# Altium schematic sheet RAK3172_Sensor.SchDoc
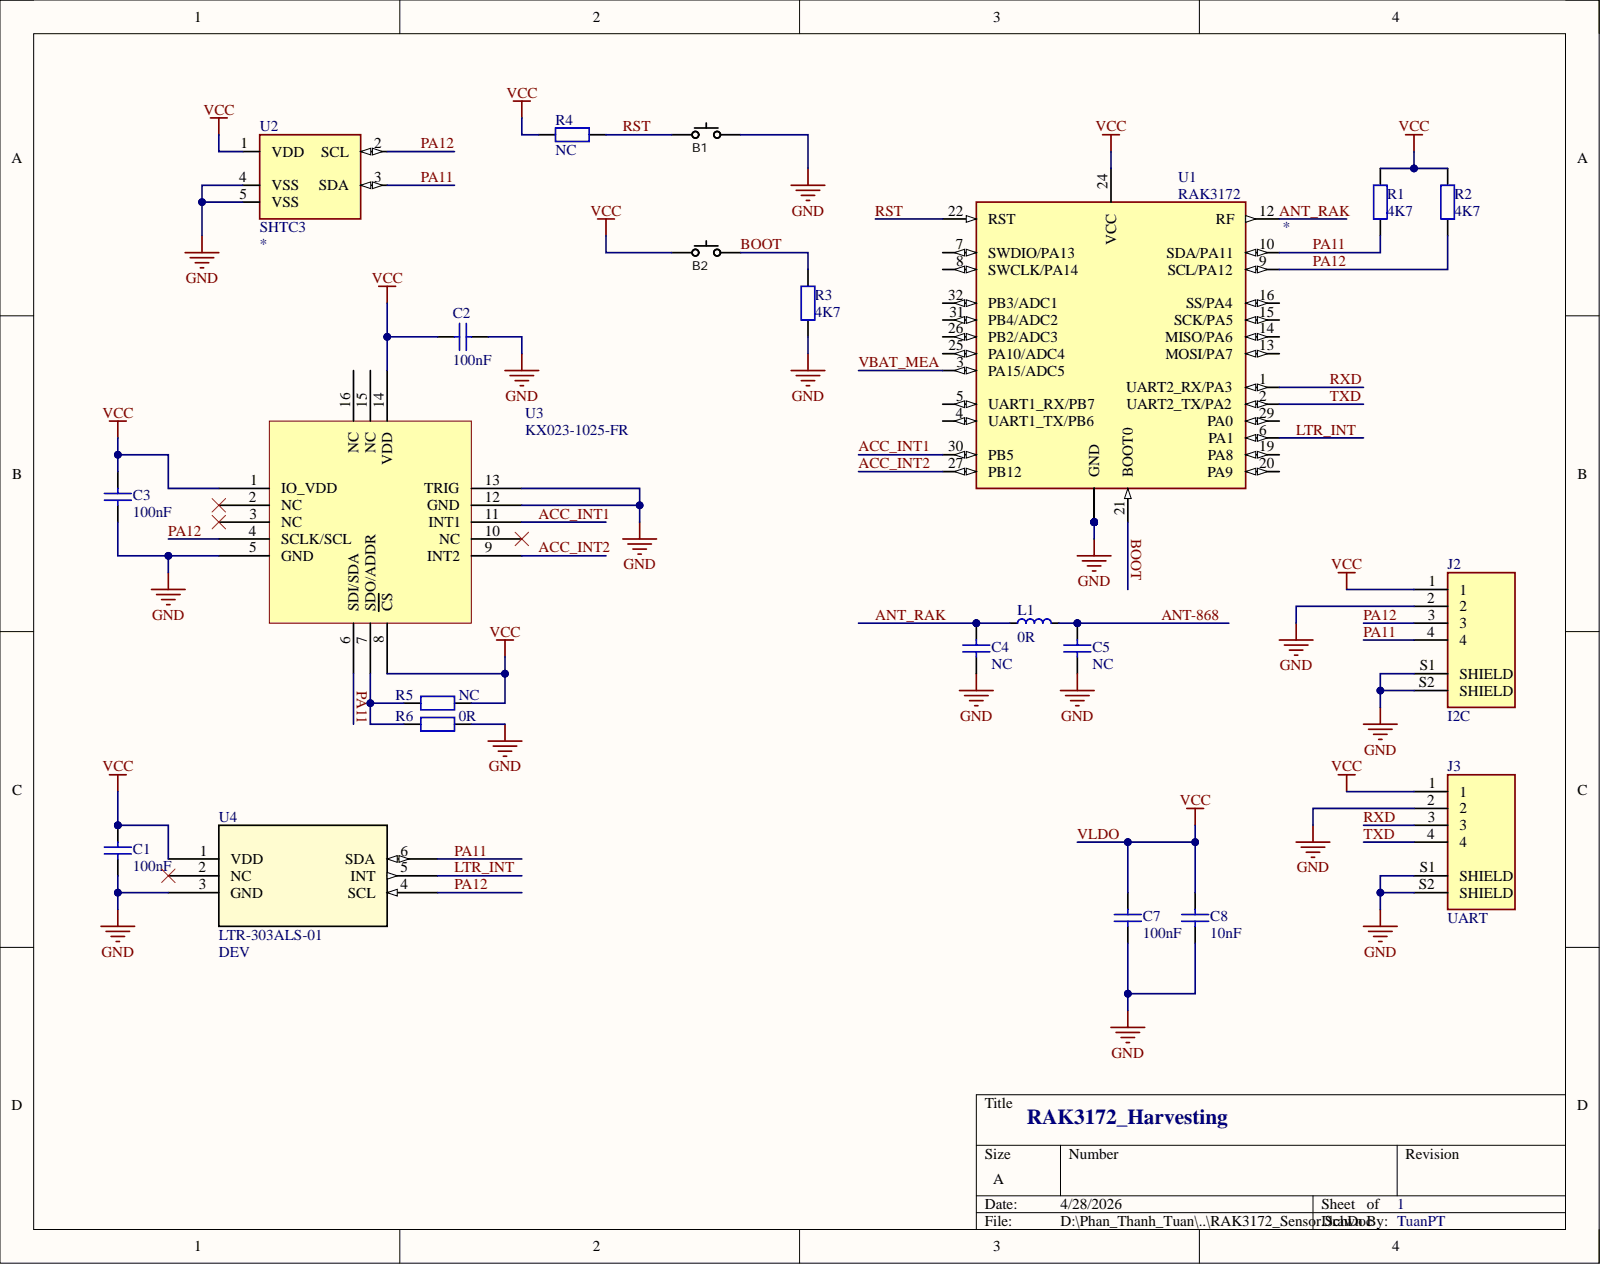
[diagram p0: RAK3172_Sensor.SchDoc]

## Parts
B1  Button-2P-3x6x2.5mm  [SW-SMD_L3.9-W2.9-LS5.0]  (value not drawn)
B2  Button-2P-3x6x2.5mm  [SW-SMD_L3.9-W2.9-LS5.0]  (value not drawn)
C1  value 100nF  [CAP 0402_1005]  (hidden comment: Cap)
C2  value 100nF  [CAP 0402_1005]  (hidden comment: Cap)
C3  value 100nF  [CAP 0402_1005]  (hidden comment: Cap)
C4  value NC  [rlc_402_smd]  (hidden comment: Cap)
C5  value NC  [rlc_402_smd]  (hidden comment: Cap)
C7  value 100nF  [rlc_402_smd]  (hidden comment: Cap)
C8  value 10nF  [rlc_402_smd]  (hidden comment: Cap)
J2  I2C  [CONN_BM04B-SRSS-TB(LF)(SN)]
J3  UART  [CONN_BM04B-SRSS-TB(LF)(SN)]
L1  value 0R  [rlc_402_smd]  (hidden comment: Inductor small symbol)
R1  value 4K7  [RES-SMD-0402]  (hidden comment: Resistor)
R2  value 4K7  [RES-SMD-0402]  (hidden comment: Resistor)
R3  value 4K7  [RES-SMD-0402]  (hidden comment: Resistor)
R4  value NC  [RES-SMD-0402]  (hidden comment: Resistor)
R5  value NC  [rlc_402_smd]  (hidden comment: Resistor)
R6  value 0R  [rlc_402_smd]  (hidden comment: Resistor)
U1  RAK3172  [RAK3172]
U2  SHTC3  [SHTC3]
U3  KX023-1025-FR  [KX023-1025-FR]
U4  LTR-303ALS-01  Type: DEV  [LTR-303ALS-01_LTO-M]

## Nets
GND: B1.2 C1.1 C2.1 C3.2 C4.1 C5.2 C7.1 C8.1 J2.2 J2.S1(SHIELD) J2.S2(SHIELD) J3.2 J3.S1(SHIELD) J3.S2(SHIELD) R3.2 R6.1 U1.11(GND) U1.17 U1.18 U1.23 U1.28 U2.4(VSS) U2.5(VSS) U3.5(GND) U3.12(GND) U3.13(TRIG) U4.3(GND)
ACC_INT1: U1.30(PB5) U3.11(INT1)
ACC_INT2: U1.27(PB12) U3.9(INT2)
ANT-868: C5.1 L1.2
ANT_RAK: C4.2 L1.1 U1.12(RF)
BOOT: B2.2 R3.1 U1.21(BOOT0)
LTR_INT: U1.6(PA1) U4.5(INT)
PA11: J2.4 R1.1 U1.10(SDA/PA11) U2.3(SDA) U3.6(SDI/SDA) U4.6(SDA)
PA12: J2.3 R2.1 U1.9(SCL/PA12) U2.2(SCL) U3.4(SCLK/SCL) U4.4(SCL)
RST: B1.1 R4.1 U1.22(RST)
RXD: J3.3 U1.1(UART2_RX/PA3)
TXD: J3.4 U1.2(UART2_TX/PA2)
VBAT_MEA: U1.3(PA15/ADC5)
VLDO: B2.1 C1.2 C2.2 C3.1 C7.2 C8.2 J2.1 J3.1 R1.2 R2.2 R4.2 R5.2 U1.24(VCC) U2.1(VDD) U3.1(IO_VDD) U3.8(CS) U3.14(VDD) U4.1(VDD)
NetR5_1: R5.1 R6.2 U3.7(SDO/ADDR)

Not connected: U1 4(UART1_TX/PB6) 5(UART1_RX/PB7) 7(SWDIO/PA13) 8(SWCLK/PA14) 13(MOSI/PA7) 14(MISO/PA6) 15(SCK/PA5) 16(SS/PA4) 19(PA8) 20(PA9) 25(PA10/ADC4) 26(PB2/ADC3) 29(PA0) 31(PB4/ADC2) 32(PB3/ADC1); U3 2(NC) 3(NC) 10(NC) 15(NC) 16(NC); U4 2(NC)

## Library data of the parts
B1 B2 = Button-2P-3x6x2.5mm: Tactile Button; Component Kind: Standard; Library Name: RFThings.SchLib
C1-C5 C7 C8 = Cap: Capacitor SMD; Component Kind: Standard; LatestRevisionDate: 05-oct-2007  -  Semtech; LatestRevisionNote: Re-released for DXP Platform.; Published: 8-Jun-2000; Publisher: Altium Limited
  C4 C5 C7 C8: Library Name: LR1110_e516v02b.SchLib; Signal Integrity: Cap Semi; Simulation: CAP
  C1-C3: Library Name: RFThings.SchLib
J2 J3 = BM04B-SRSS-TB(LF)(SN)_1: BM04B Series 1 mm Pitch 4 Position SMT Single Row Top Entry Shrouded Header; Availability: Unavailable; Component Kind: Standard; MP: BM04B-SRSS-TB(LF)(SN); Package: None; Price: None; Library Name: RFThings.SchLib
L1 = Inductor_small: Inductor; Code_IEC: 1005; Code_JEITA: 0402; Component Kind: Standard; LatestRevisionDate: 05-oct-2007  -  Semtech; LatestRevisionNote: Re-released for DXP Platform.; Library Name: LR1110_e516v02b.SchLib; Published: 8-Jun-2000; Publisher: Altium Limited
R1-R4 = RES-SMD: Resistor SMD - IEC symbol version; Component Kind: Standard; LatestRevisionDate: 27-Jul-20  -  Semtech; Library Name: RFThings.SchLib
R5 R6 = Resistor: Resistor SMD - IEC symbol version; Component Kind: Standard; LatestRevisionDate: 27-Jul-20  -  Semtech; Library Name: LR1110_e516v02b.SchLib
U1 = CMP-051-000031-1: RAK3172 is a low-power long-range transceiver module based on the STM32WLE5CC chip. It provides an easy-to-use, small-size, low-power solution for long range wireless data applications; MF: Shenzhen RAKwireless Technology Co.,Ltd.; Availability: Not in stock; Check_prices: https://www.snapeda.com/parts/RAK3172/Shenzhen+RAKwireless+Technology+Co.%252CLtd./view-part/?ref=eda; Component Kind: Standard; Design Item ID: RAK3172; MP: RAK3172; Package: Package; Price: None; SnapEDA_Link: https://www.snapeda.com/parts/RAK3172/Shenzhen+RAKwireless+Technology+Co.%252CLtd./view-part/?ref=snap; Library Name: ThanhTuan; Source: RFThings.SchLib
U2 = CMP-051-000011-1: SENSOR HUMI/TEMP I2C 2% SMD; MF: Sensirion AG; Check_prices: https://www.snapeda.com/parts/SHTC3/Sensirion+AG/view-part/?ref=eda; Component Kind: Standard; Design Item ID: SHTC3; MP: SHTC3; Package: DFN-4 Sensirion; SnapEDA_Link: https://www.snapeda.com/parts/SHTC3/Sensirion+AG/view-part/?ref=snap; Library Name: ThanhTuan; Source: RFThings.SchLib
U3 = KX023-1025-FR_2: Accelerometer X, Y, Z Axis ±2g, 4g, 8g 0.39Hz ~ 800Hz 16-LGA (3x3); Manufacture: Kionix Inc.; Component Kind: Standard; Digikey: 1191-1039-1-ND; LCSC: C236128; MPN: KX023-1025-FR; Library Name: RFThings.SchLib
U4 = CMP-051-000065-1: No Description Available; Mfr_Name: LITE-ON; Component Kind: Standard; Design Item ID: LTR-303ALS-01; Manufacturer_Part_Number: LTR-303ALS-01; RefDes: RefDes; Copyright: Copyright (C) 2022 Ultra Librarian. All rights reserved.; Library Name: ThanhTuan; Source: RFThings.SchLib
